# Altium schematic sheet printer_control.SchDoc(printer_control)
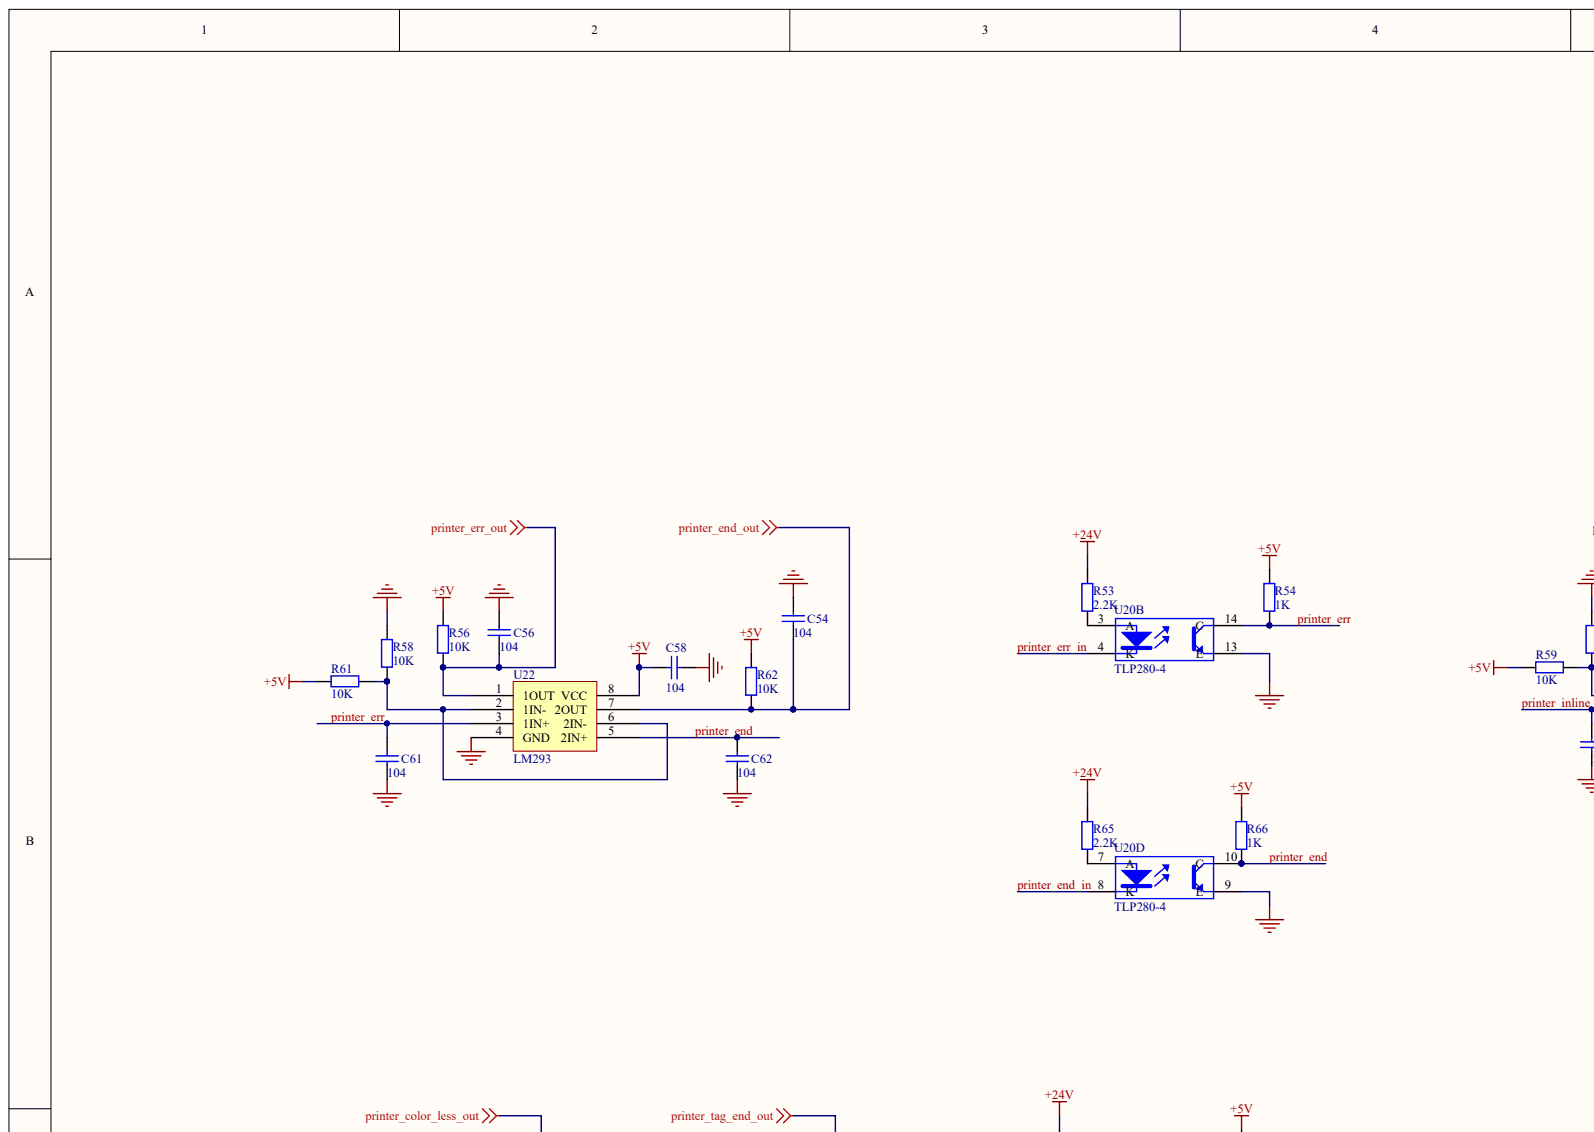
[diagram p0: printer_control.SchDoc, top left]
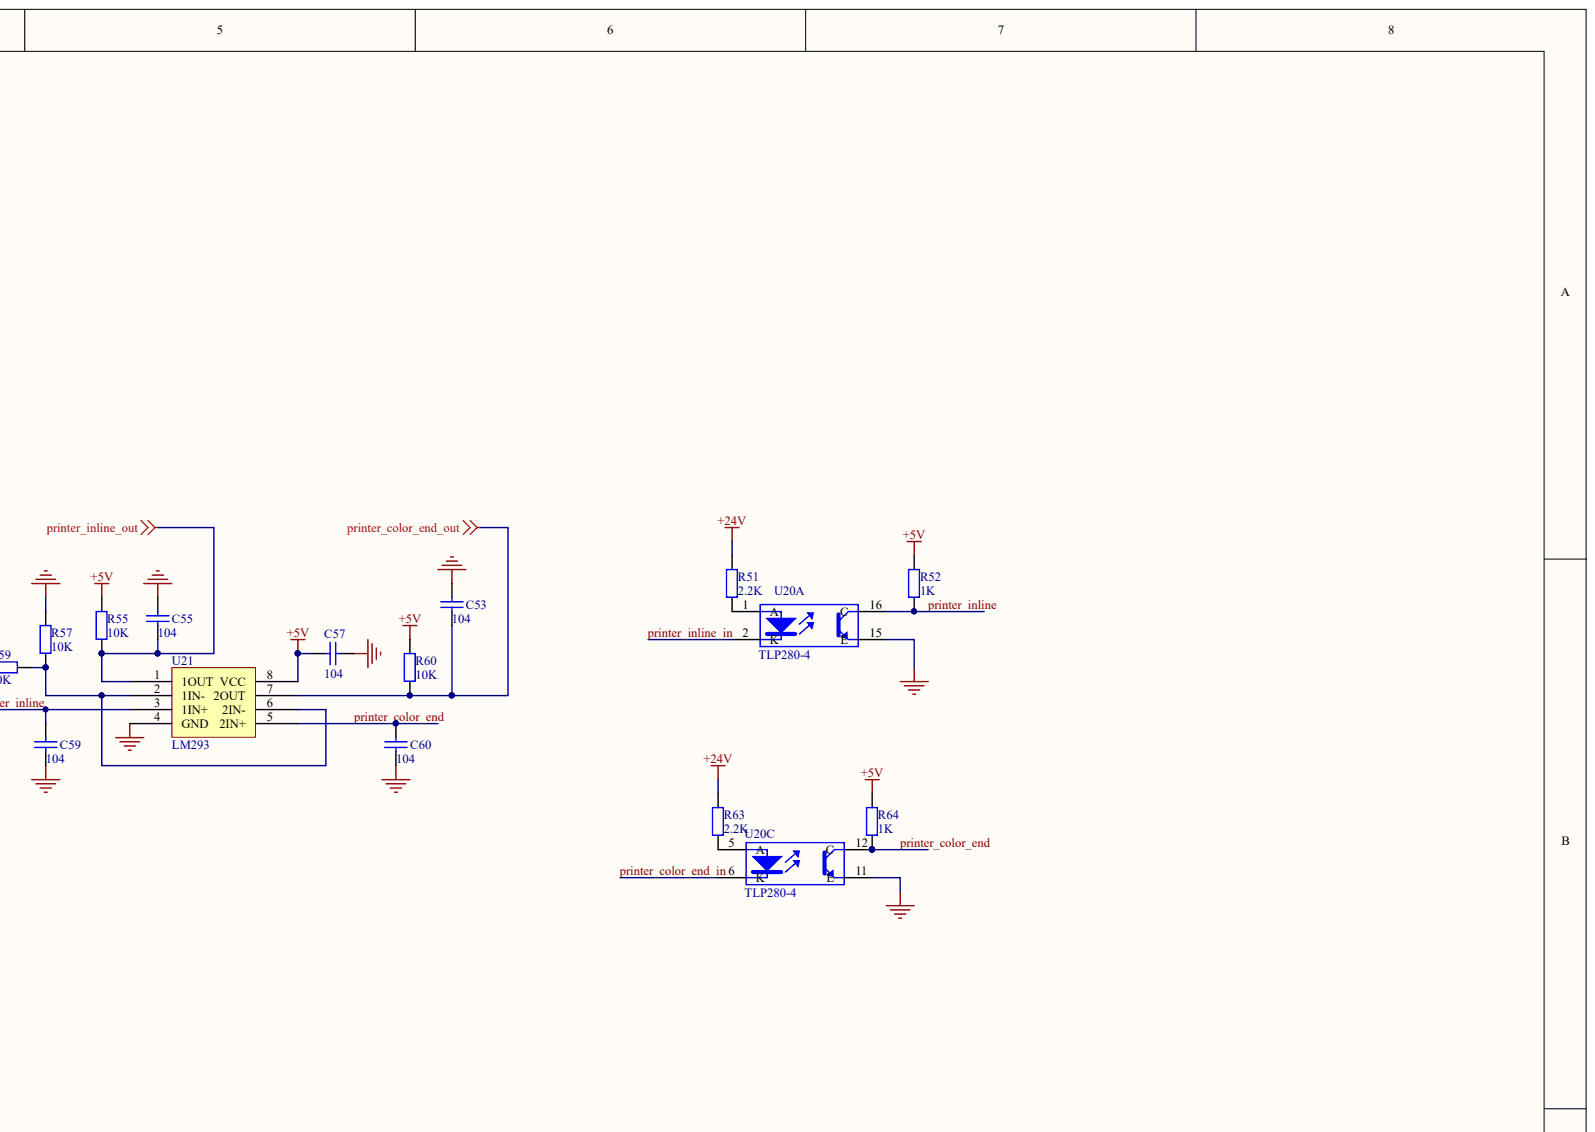
[diagram p1: printer_control.SchDoc, top right]
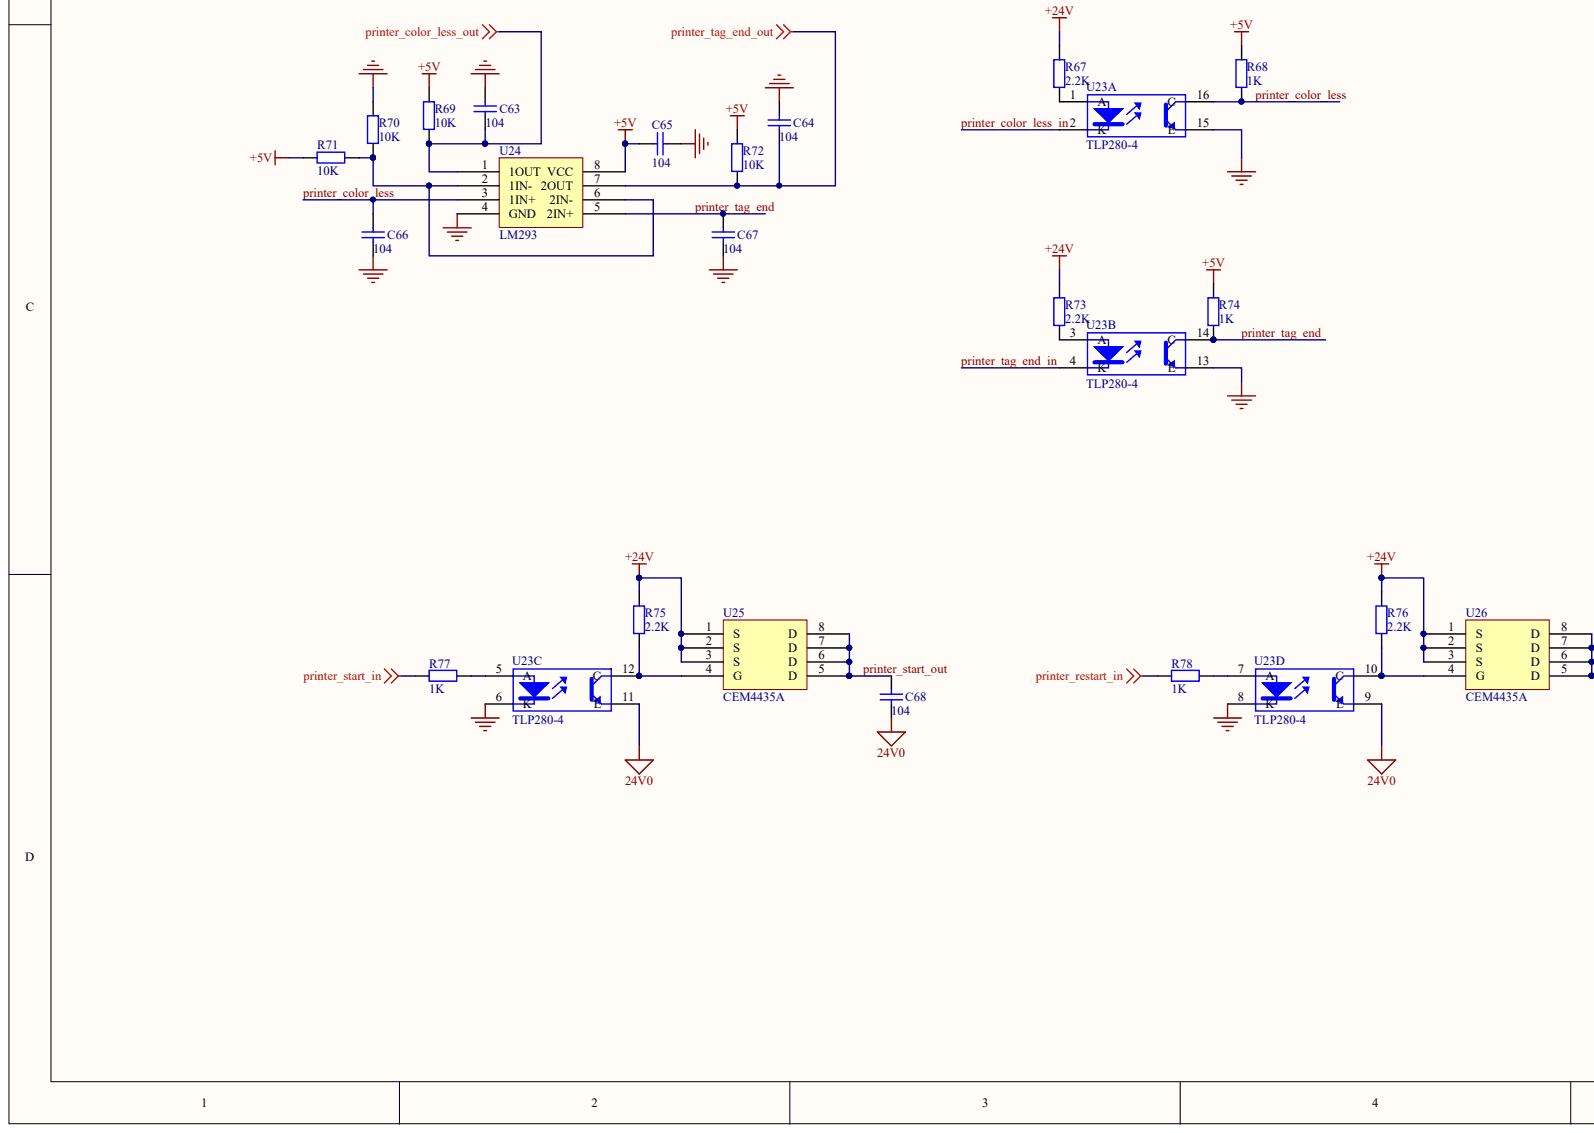
[diagram p2: printer_control.SchDoc, bottom left]
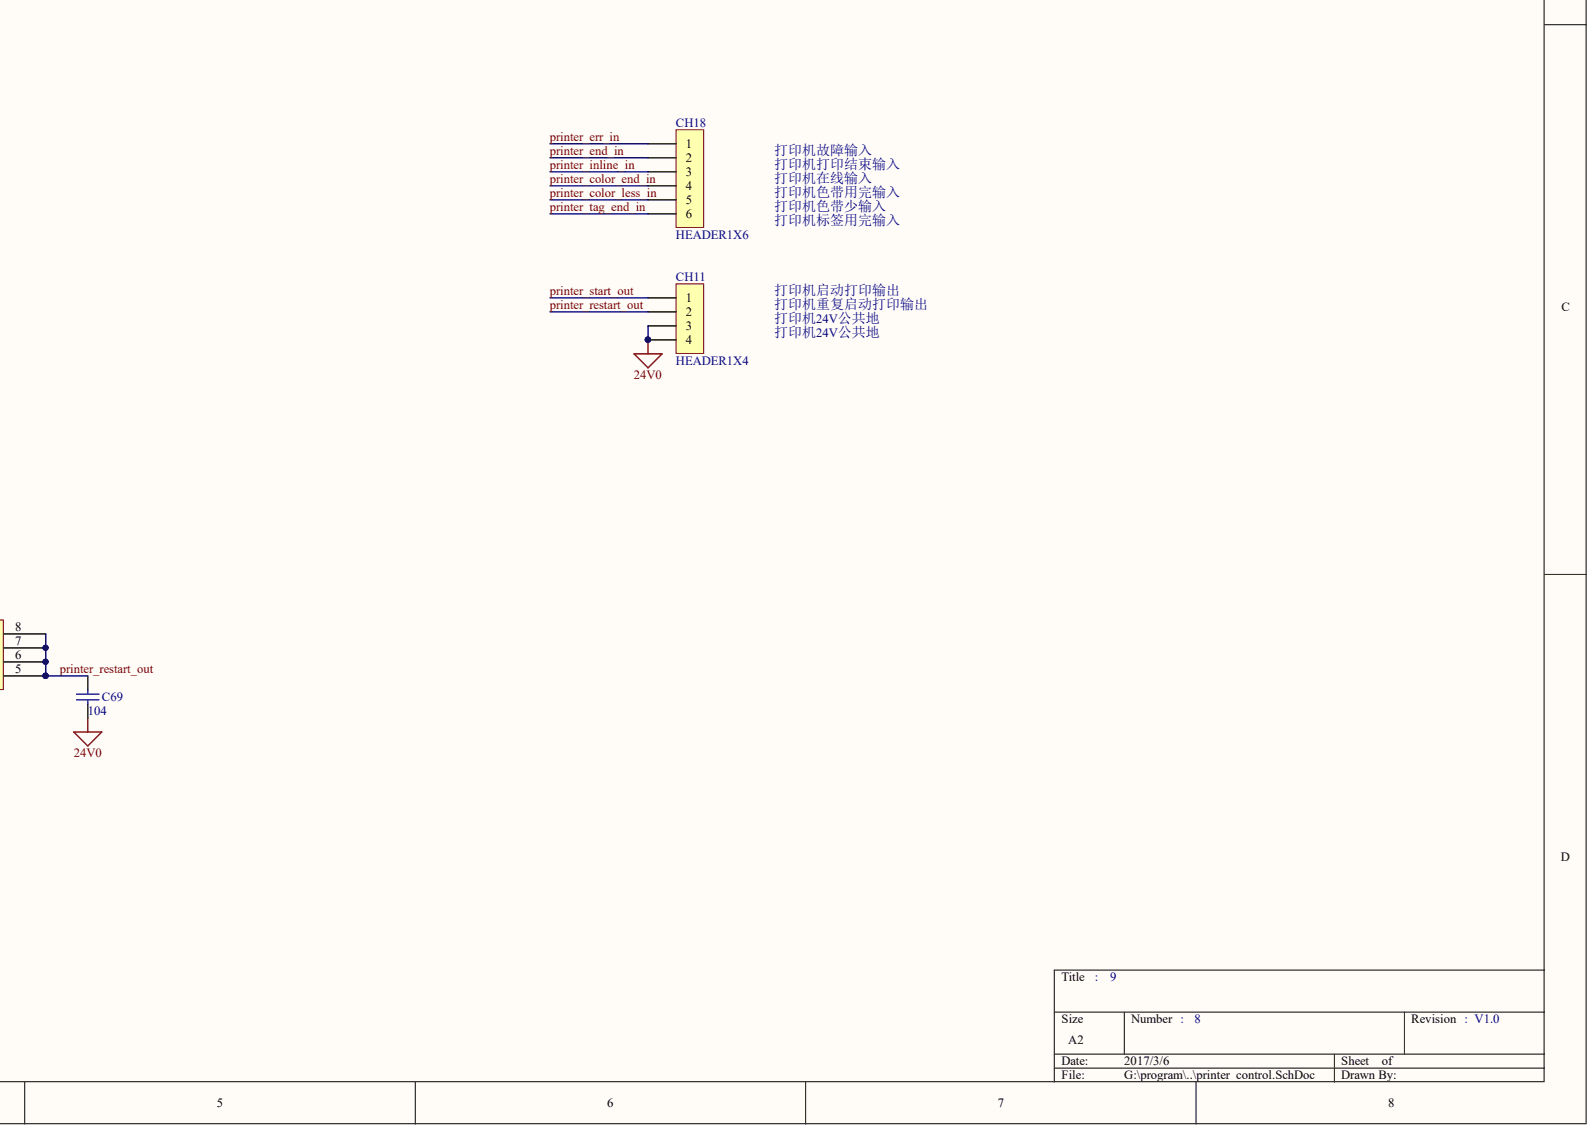
[diagram p3: printer_control.SchDoc, bottom right]

## Parts
C53  value 104  (hidden comment: Cap)
C54  value 104  (hidden comment: Cap)
C55  value 104  (hidden comment: Cap)
C56  value 104  (hidden comment: Cap)
C57  value 104  (hidden comment: Cap)
C58  value 104  (hidden comment: Cap)
C59  value 104  (hidden comment: Cap)
C60  value 104  (hidden comment: Cap)
C61  value 104  (hidden comment: Cap)
C62  value 104  (hidden comment: Cap)
C63  value 104  (hidden comment: Cap)
C64  value 104  (hidden comment: Cap)
C65  value 104  (hidden comment: Cap)
C66  value 104  (hidden comment: Cap)
C67  value 104  (hidden comment: Cap)
C68  value 104  (hidden comment: Cap)
C69  value 104  (hidden comment: Cap)
CH11  HEADER1X4
CH18  HEADER1X6
R51  value 2.2K  (hidden comment: Res2)
R52  value 1K  (hidden comment: Res2)
R53  value 2.2K  (hidden comment: Res2)
R54  value 1K  (hidden comment: Res2)
R55  value 10K  (hidden comment: Res2)
R56  value 10K  (hidden comment: Res2)
R57  value 10K  (hidden comment: Res2)
R58  value 10K  (hidden comment: Res2)
R59  value 10K  (hidden comment: Res2)
R60  value 10K  (hidden comment: Res2)
R61  value 10K  (hidden comment: Res2)
R62  value 10K  (hidden comment: Res2)
R63  value 2.2K  (hidden comment: Res2)
R64  value 1K  (hidden comment: Res2)
R65  value 2.2K  (hidden comment: Res2)
R66  value 1K  (hidden comment: Res2)
R67  value 2.2K  (hidden comment: Res2)
R68  value 1K  (hidden comment: Res2)
R69  value 10K  (hidden comment: Res2)
R70  value 10K  (hidden comment: Res2)
R71  value 10K  (hidden comment: Res2)
R72  value 10K  (hidden comment: Res2)
R73  value 2.2K  (hidden comment: Res2)
R74  value 1K  (hidden comment: Res2)
R75  value 2.2K  (hidden comment: Res2)
R76  value 2.2K  (hidden comment: Res2)
R77  value 1K  (hidden comment: Res2)
R78  value 1K  (hidden comment: Res2)
U20  TLP280-4  (parts A,B,C,D)
U21  LM293
U22  LM293
U23  TLP280-4  (parts A,B,C,D)
U24  LM293
U25  CEM4435A
U26  CEM4435A

## Nets
24V0: C68.1 C69.1 CH11.3 CH11.4 U23.9(E) U23.11(E)
+5V: C57.2 C58.2 C65.2 R52.1 R54.1 R55.1 R56.1 R59.1 R60.1 R61.1 R62.1 R64.1 R66.1 R68.1 R69.1 R71.1 R72.1 R74.1 U21.8(VCC) U22.8(VCC) U24.8(VCC)
+24V: R51.1 R53.1 R63.1 R65.1 R67.1 R73.1 R75.1 R76.1 U25.1(S) U25.2(S) U25.3(S) U26.1(S) U26.2(S) U26.3(S)
GND: C53.2 C54.2 C55.2 C56.2 C57.1 C58.1 C59.1 C60.1 C61.1 C62.1 C63.2 C64.2 C65.1 C66.1 C67.1 R57.1 R58.1 R70.1 U20.9(E) U20.11(E) U20.13(E) U20.15(E) U21.4(GND) U22.4(GND) U23.6(K) U23.8(K) U23.13(E) U23.15(E) U24.4(GND)
PRINTER_COLOR_END_OUT: C53.1 R60.2 U21.7(2OUT)
PRINTER_COLOR_LESS_OUT: C63.1 R69.2 U24.1(1OUT)
PRINTER_END_OUT: C54.1 R62.2 U22.7(2OUT)
PRINTER_ERR_OUT: C56.1 R56.2 U22.1(1OUT)
PRINTER_INLINE_OUT: C55.1 R55.2 U21.1(1OUT)
PRINTER_RESTART_IN: R78.1
PRINTER_START_IN: R77.1
PRINTER_TAG_END_OUT: C64.1 R72.2 U24.7(2OUT)
PRINTER_COLOR_END: C60.2 R64.2 U20.12(C) U21.5(2IN+)
PRINTER_COLOR_END_IN: CH18.4 U20.6(K)
PRINTER_COLOR_LESS: C66.2 R68.2 U23.16(C) U24.3(1IN+)
PRINTER_COLOR_LESS_IN: CH18.5 U23.2(K)
PRINTER_END: C62.2 R66.2 U20.10(C) U22.5(2IN+)
PRINTER_END_IN: CH18.2 U20.8(K)
PRINTER_ERR: C61.2 R54.2 U20.14(C) U22.3(1IN+)
PRINTER_ERR_IN: CH18.1 U20.4(K)
PRINTER_INLINE: C59.2 R52.2 U20.16(C) U21.3(1IN+)
PRINTER_INLINE_IN: CH18.3 U20.2(K)
PRINTER_RESTART_OUT: C69.2 CH11.2 U26.5(D) U26.6(D) U26.7(D) U26.8(D)
PRINTER_START_OUT: C68.2 CH11.1 U25.5(D) U25.6(D) U25.7(D) U25.8(D)
PRINTER_TAG_END: C67.2 R74.2 U23.14(C) U24.5(2IN+)
PRINTER_TAG_END_IN: CH18.6 U23.4(K)
NetR51_2: R51.2 U20.1(A)
NetR53_2: R53.2 U20.3(A)
NetR57_2: R57.2 R59.2 U21.2(1IN-) U21.6(2IN-)
NetR58_2: R58.2 R61.2 U22.2(1IN-) U22.6(2IN-)
NetR63_2: R63.2 U20.5(A)
NetR65_2: R65.2 U20.7(A)
NetR67_2: R67.2 U23.1(A)
NetR70_2: R70.2 R71.2 U24.2(1IN-) U24.6(2IN-)
NetR73_2: R73.2 U23.3(A)
NetR75_2: R75.2 U23.12(C) U25.4(G)
NetR76_2: R76.2 U23.10(C) U26.4(G)
NetR77_2: R77.2 U23.5(A)
NetR78_2: R78.2 U23.7(A)

## Library data of the parts
C53-C69 CH11 CH18 R51-R78 U20-U26 = (no symbol name)
  C53-C69: Published: 8-Jun-2000; LatestRevisionDate: 17-Jul-2002; LatestRevisionNote: Re-released for DXP Platform.; PackageReference: RAD-0.3
  R51-R78: Published: 8-Jun-2000; LatestRevisionDate: 17-Jul-2002; LatestRevisionNote: Re-released for DXP Platform.; PackageReference: AXIAL-0.4
  C53-C69 R51-R78: Publisher: Altium Limited
